# Altium schematic sheet sheet1.SchDoc
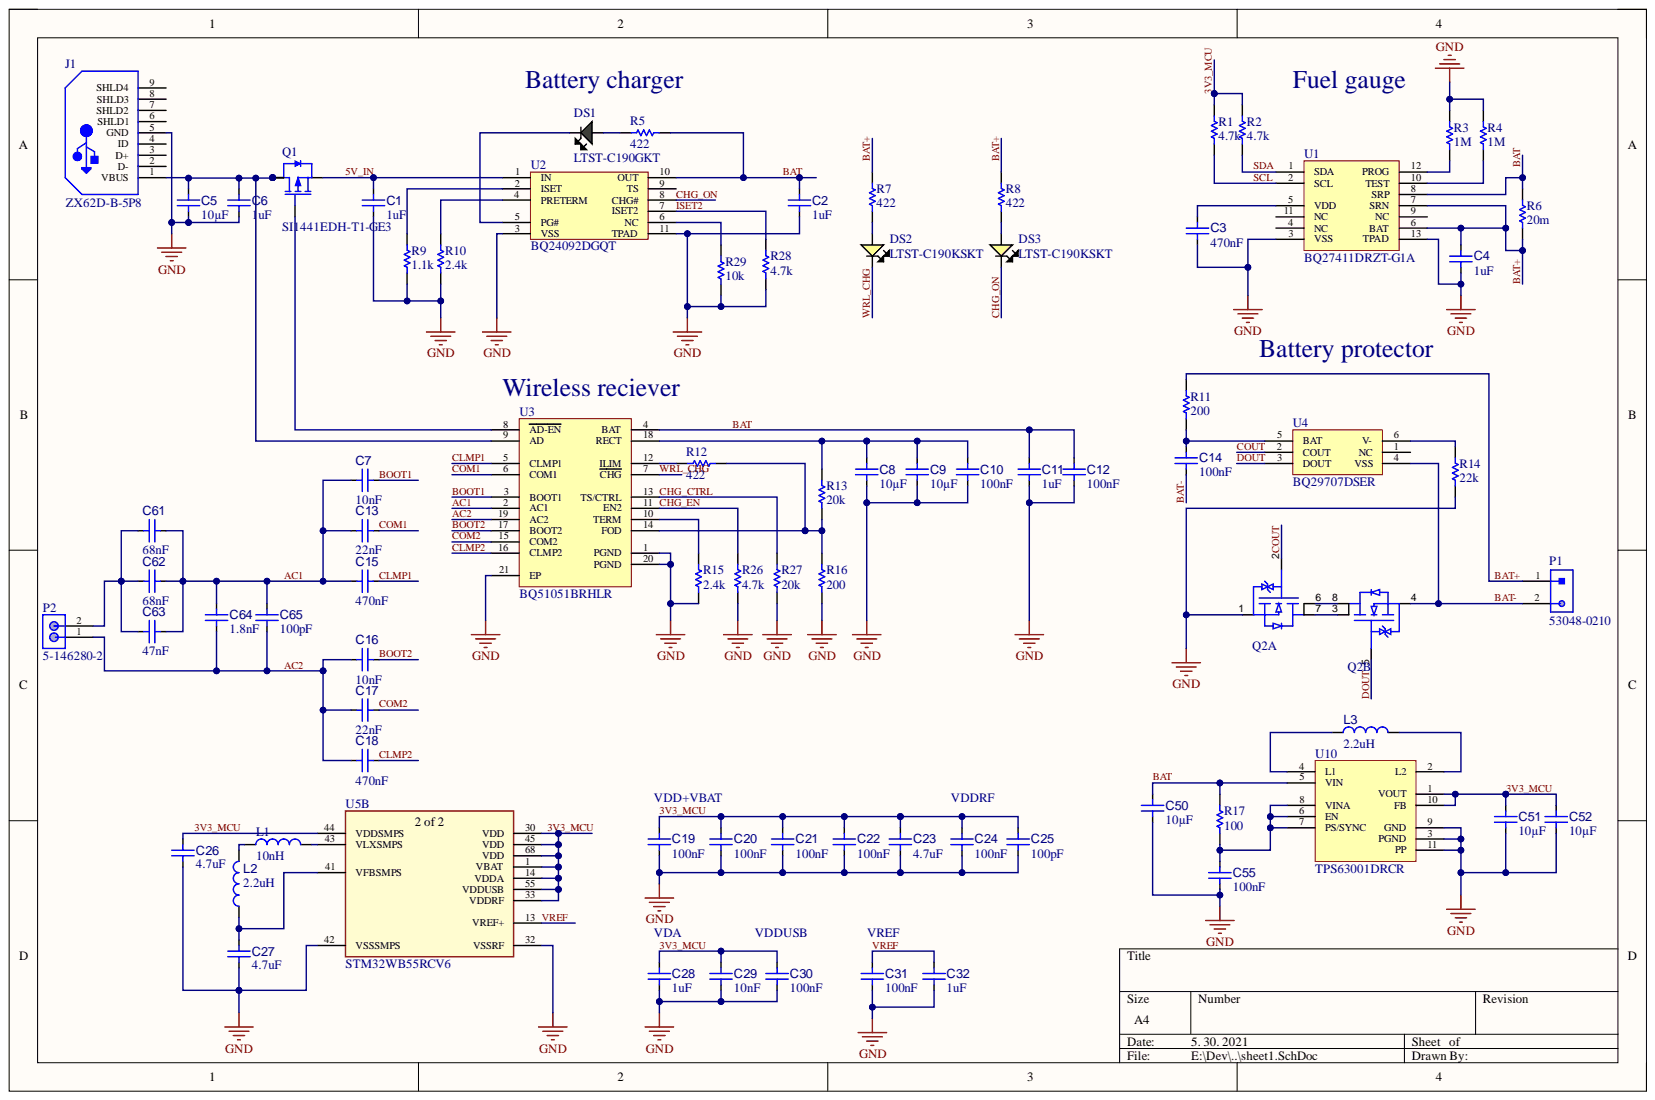
[diagram p0: sheet1.SchDoc]

## Parts
C1  value 1uF  Capacitance: 1uF  [0603-SMD-CAP]  (hidden comment: C0603C105K3PACTU)
C2  value 1uF  Capacitance: 1uF  [0603-SMD-CAP]  (hidden comment: C0603C105K3PACTU)
C3  value 470nF  [CAPC0603(1608)100_L]  (hidden comment: Capacitor 470nF +/-20% 25V 0603)
C4  value 1uF  Capacitance: 1uF  [0603-SMD-CAP]  (hidden comment: C0603C105K3PACTU)
C5  value 10µF  [0603-SMD-CAP]  (hidden comment: 0603ZD106KAT2A)
C6  value 1uF  Capacitance: 1uF  [0603-SMD-CAP]  (hidden comment: C0603C105K3PACTU)
C7  value 10nF  Capacitance: 10nF  [0402-SMD-CAP]  (hidden comment: CL05B103KB5NNNC)
C8  value 10µF  [0603-SMD-CAP]  (hidden comment: 0603ZD106KAT2A)
C9  value 10µF  [0603-SMD-CAP]  (hidden comment: 0603ZD106KAT2A)
C10  value 100nF  [0402-SMD-CAP]  (hidden comment: EMF105B7104MVHF)
C11  value 1uF  Capacitance: 1uF  [0603-SMD-CAP]  (hidden comment: C0603C105K3PACTU)
C12  value 100nF  [0402-SMD-CAP]  (hidden comment: EMF105B7104MVHF)
C13  value 22nF  Capacitance: 22nF  [0402-SMD-CAP]  (hidden comment: CC0402KRX7R8BB223)
C14  value 100nF  [0402-SMD-CAP]  (hidden comment: EMF105B7104MVHF)
C15  value 470nF  Capacitance: 470nF  [0402-SMD-CAP]  (hidden comment: C1005X5R1E474K050BB)
C16  value 10nF  Capacitance: 10nF  [0402-SMD-CAP]  (hidden comment: CL05B103KB5NNNC)
C17  value 22nF  Capacitance: 22nF  [0402-SMD-CAP]  (hidden comment: CC0402KRX7R8BB223)
C18  value 470nF  Capacitance: 470nF  [0402-SMD-CAP]  (hidden comment: C1005X5R1E474K050BB)
C19  value 100nF  [0402-SMD-CAP]  (hidden comment: EMF105B7104MVHF)
C20  value 100nF  [0402-SMD-CAP]  (hidden comment: EMF105B7104MVHF)
C21  value 100nF  [0402-SMD-CAP]  (hidden comment: EMF105B7104MVHF)
C22  value 100nF  [0402-SMD-CAP]  (hidden comment: EMF105B7104MVHF)
C23  value 4.7uF  Capacitance: 4.7uF  [0603-SMD-CAP]  (hidden comment: C0603C475K9PACTU)
C24  value 100nF  [0402-SMD-CAP]  (hidden comment: EMF105B7104MVHF)
C25  value 100pF  Capacitance: 100pF  [0402-SMD-CAP]  (hidden comment: 04025A101JAT2A)
C26  value 4.7uF  Capacitance: 4.7uF  [0603-SMD-CAP]  (hidden comment: C0603C475K9PACTU)
C27  value 4.7uF  Capacitance: 4.7uF  [0603-SMD-CAP]  (hidden comment: C0603C475K9PACTU)
C28  value 1uF  Capacitance: 1uF  [0603-SMD-CAP]  (hidden comment: C0603C105K3PACTU)
C29  value 10nF  Capacitance: 10nF  [0402-SMD-CAP]  (hidden comment: CC0402KRX7R7BB103)
C30  value 100nF  [0402-SMD-CAP]  (hidden comment: EMF105B7104MVHF)
C31  value 100nF  [0402-SMD-CAP]  (hidden comment: EMF105B7104MVHF)
C32  value 1uF  Capacitance: 1uF  [0603-SMD-CAP]  (hidden comment: C0603C105K3PACTU)
C50  value 10µF  [0603-SMD-CAP]  (hidden comment: 0603ZD106KAT2A)
C51  value 10µF  [0603-SMD-CAP]  (hidden comment: 0603ZD106KAT2A)
C52  value 10µF  [0603-SMD-CAP]  (hidden comment: 0603ZD106KAT2A)
C55  value 100nF  [0402-SMD-CAP]  (hidden comment: EMF105B7104MVHF)
C61  value 68nF  Capacitance: 68nF  [0603-SMD-CAP]  (hidden comment: 06035C683KAT2A)
C62  value 68nF  Capacitance: 68nF  [0603-SMD-CAP]  (hidden comment: 06035C683KAT2A)
C63  value 47nF  Capacitance: 47nF  [0603-SMD-CAP]  (hidden comment: 06035G473ZAT2A)
C64  value 1.8nF  Capacitance: 1.8nF  [0603-SMD-CAP]  (hidden comment: 06031C182JAT2A)
C65  value 100pF  Capacitance: 100pF  [0402-SMD-CAP]  (hidden comment: 04025A101JAT2A)
DS1  LTST-C190GKT  [LTST-C190GKT_V]
DS2  LTST-C190KSKT  [LTST-C190KSKT_V]
DS3  LTST-C190KSKT  [LTST-C190KSKT_V]
J1  ZX62D-B-5P8  [HIRO-ZX62D-B-5P8_V]
L1  value 10nH  Inductance: 10nH  [0603-SMD-IND]  (hidden comment: B82496C3100J000)
L2  value 2.2uH  [0603-SMD-IND]  (hidden comment: CVH160808-2R2M)
L3  value 2.2uH  [0603-SMD-IND]  (hidden comment: CVH160808-2R2M)
P1  53048-0210  [PHOE-1803277_V]
P2  5-146280-2  [TECO-5-146280-2_V]
Q1  SI1441EDH-T1-GE3  [SOT23127P600-8N]
Q2  CSD85301Q2  [SON65P200X80_HS-7N]  (value not drawn)  (parts A,B)
R1  value 4.7k  Resistance: 4.7k  [0603-SMD-RES]  (hidden comment: ERJ-3EKF4701V)
R2  value 4.7k  Resistance: 4.7k  [0603-SMD-RES]  (hidden comment: ERJ-3EKF4701V)
R3  value 1M  [0603-SMD-RES]  (hidden comment: CR0603-JW-105ELF)
R4  value 1M  [0603-SMD-RES]  (hidden comment: CR0603-JW-105ELF)
R5  value 422  [0402_SMD_RES]  (hidden comment: ERJ-2RKF4220X)
R6  value 20m  [Chip2PinSM127P600-8N]  (hidden comment: WSLP1206R0200FEA)
R7  value 422  [0402_SMD_RES]  (hidden comment: ERJ-2RKF4220X)
R8  value 422  [0402_SMD_RES]  (hidden comment: ERJ-2RKF4220X)
R9  value 1.1k  [0603-SMD-RES]  (hidden comment: CRCW06031K10FKEA)
R10  value 2.4k  [0603-SMD-RES]  (hidden comment: ERJ-3EKF2401V)
R11  value 200  [0402_SMD_RES]  (hidden comment: CRCW0402200RFKED)
R12  value 422  [0402_SMD_RES]  (hidden comment: ERJ-2RKF4220X)
R13  value 20k  [0402_SMD_RES]  (hidden comment: CRCW040220K0FKED)
R14  value 22k  [0603-SMD-RES]  (hidden comment: CRCW060322K0FKEA)
R15  value 2.4k  [0603-SMD-RES]  (hidden comment: ERJ-3EKF2401V)
R16  value 200  [0402_SMD_RES]  (hidden comment: CRCW0402200RFKED)
R17  value 100  [0603-SMD-RES]  (hidden comment: CRCW0603100RFKEA)
R26  value 4.7k  Resistance: 4.7k  [0603-SMD-RES]  (hidden comment: ERJ-3EKF4701V)
R27  value 20k  Resistance: 20k  [0603-SMD-RES]  (hidden comment: CRCW060320K0FKEA)
R28  value 4.7k  Resistance: 4.7k  [0603-SMD-RES]  (hidden comment: ERJ-3EKF4701V)
R29  value 10k  [0402_SMD_RES]  (hidden comment: CRCW040210K0FKTD)
U1  BQ27411DRZT-G1A  [SON40P400X100_HS-13N]
U2  BQ24092DGQT  [TSSOP50P490X110_HS-11L]
U3  BQ51051BRHLR  [RHL0020A_V]
U4  BQ29707DSER  [SON50P150X80-6N]
U5  STM32WB55RCV6  [QFN40P800X800X100_HS-69N]  (part B)
U10  TPS63001DRCR  [DRC0010J_V]

## Nets
GND: C1.2 C2.2 C3.2 C4.2 C5.1 C6.2 C8.1 C9.1 C10.2 C11.2 C12.2 C19.2 C20.2 C21.2 C22.2 C23.2 C24.2 C25.2 C26.2 C27.2 C28.2 C29.2 C30.2 C31.2 C32.2 C50.1 C51.1 C52.1 C55.2 J1.5(GND) Q2.1 R3.2 R4.2 R9.1 R10.1 R14.1 R15.1 R16.1 R26.1 R27.1 R28.1 R29.2 U1.3(VSS) U1.13(TPAD) U2.3(VSS) U2.11(TPAD) U3.1(PGND) U3.20(PGND) U3.21(EP) U5.32(VSSRF) U5.42(VSSSMPS) U10.3(PGND) U10.9(GND) U10.11(PP)
3V3_MCU: C19.1 C20.1 C21.1 C22.1 C23.1 C24.1 C25.1 C26.1 C28.1 C29.1 C30.1 C51.2 C52.2 R1.2 R2.2 U5.1(VBAT) U5.14(VDDA) U5.30(VDD) U5.33(VDDRF) U5.44(VDDSMPS) U5.45(VDD) U5.55(VDDUSB) U5.68(VDD) U10.1(VOUT) U10.10(FB)
5V_IN: C1.1 Q1.4 U2.1(IN)
AC1: C7.1 C13.1 C15.1 C61.2 C62.2 C63.2 C64.1 C65.1 U3.2(AC1)
AC2: C16.1 C17.1 C18.1 C64.2 C65.2 P2.1 U3.19(AC2)
BAT: C2.1 C11.1 C12.1 C50.2 R5.1 R6.2 R17.2 U1.8(SRP) U2.10(OUT) U3.4(BAT) U10.5(VIN)
BAT+: C4.1 P1.1 R6.1 R7.2 R8.2 R11.2 U1.6(BAT) U1.7(SRN)
BAT-: C14.2 P1.2 Q2.4 U4.4(VSS)
BOOT1: C7.2 U3.3(BOOT1)
BOOT2: C16.2 U3.17(BOOT2)
CHG_CTRL: R27.2 U3.13(TS/CTRL)
CHG_EN: R26.2 U3.11(EN2)
CHG_ON: DS3.2 U2.8(CHG#)
CLMP1: C15.2 U3.5(CLMP1)
CLMP2: C18.2 U3.16(CLMP2)
COM1: C13.2 U3.6(COM1)
COM2: C17.2 U3.15(COM2)
COUT: Q2.2 U4.2(COUT)
DOUT: Q2.5 U4.3(DOUT)
ISET2: R28.2 U2.7(ISET2)
SCL: R1.1 U1.2(SCL)
SDA: R2.1 U1.1(SDA)
VREF: C31.1 C32.1 U5.13(VREF+)
WRL_CHG: DS2.2 U3.7(CHG)
NetC3_1: C3.1 U1.5(VDD)
NetC5_2: C5.2 C6.1 J1.1(VBUS) Q1.1 Q1.2 Q1.5 Q1.6 U3.9(AD)
NetC8_2: C8.2 C9.2 C10.1 R13.2 U3.18(RECT)
NetC14_1: C14.1 R11.1 U4.5(BAT)
NetC27_1: C27.1 L2.1 U5.41(VFBSMPS)
NetC55_1: C55.1 R17.1 U10.6(EN) U10.7(PS/SYNC) U10.8(VINA)
NetC61_1: C61.1 C62.1 C63.1 P2.2
NetDS1_1: DS1.1 R5.2
NetDS1_2: DS1.2 U2.5(PG#)
NetDS2_1: DS2.1 R7.1
NetDS3_1: DS3.1 R8.1
NetL1_1: L1.1 L2.2
NetL1_2: L1.2 U5.43(VLXSMPS)
NetL3_1: L3.1 U10.4(L1)
NetL3_2: L3.2 U10.2(L2)
NetQ1_3: Q1.3 U3.8(AD-EN)
NetQ2_3: Q2.3 Q2.7
NetQ2_6: Q2.6 Q2.8
NetR3_1: R3.1 U1.12(PROG)
NetR4_1: R4.1 U1.10(TEST)
NetR9_2: R9.2 U2.2(ISET)
NetR10_2: R10.2 U2.4(PRETERM)
NetR12_1: R12.1 U3.12(ILIM)
NetR12_2: R12.2 R13.1 R16.2 U3.14(FOD)
NetR14_2: R14.2 U4.6(V-)
NetR15_2: R15.2 U3.10(TERM)
NetR29_1: R29.1 U2.6(NC)

Not connected: J1 2(D-) 3(D+) 4(ID) 6(SHLD1) 7(SHLD2) 8(SHLD3) 9(SHLD4); U1 4(NC) 9(NC) 11(NC); U2 9(TS); U4 1(NC)

## Library data of the parts
C1 C2 C4 C6 C11 C28 C32 = CMP-001-00097-3: KEMET - C0603C105K3PACTU - SMD Multilayer Ceramic Capacitor, 1 µF, 25 V, 0603 [1608 Metric], ± 10%, X5R, C Series KEMET; Voltage: 25V; Case Code (Imperial): 0603; Case Code (Metric): 1608; Case/Package: 0603; Component Kind: Standard; Construction: Flat; Contact Plating: Tin,Matte; Depth: 0.8mm; Dielectric: X5R; Dielectric Material: Ceramic; Height: 0.8mm; Lead Free: Lead Free; Length: 1.6mm; Max Operating Temperature: 85°C; Mfr. Part No.: C0603C105K3PACTU; Min Operating Temperature: -55°C; Mount: Surface Mount,PCB; Number of Pins: 2; Package Quantity: 4000; Packaging: Tape and Reel; Radiation Hardening: No; REACH SVHC: No SVHC; RoHS Compliant: Yes; Series: C0603C; Termination: SMD/SMT; Thickness: 0.034inch; Tolerance: 10%; Voltage Rating: 25V; Voltage Rating (DC): 25V; Width: 0.031inch; Library Name: Budapest University of Technology and Economics
C3 = CMP-001-00077-7: Chip Capacitor, 470nF +/-20%, 25V, 0603, Thickness 1 mm; Tolerance: 10%; Case/Package: 0603; Component Kind: Standard; Max Operating Temperature: 85°C; Min Operating Temperature: -55°C; Mounting Technology: SMT; Pins: 2; Voltage Rating: 25V; Library Name: Budapest University of Technology and Economics
C5 C8 C9 C50-C52 = CMP-001-00075-12: Chip Capacitor, 10µF +/-20%, 10V, 0603, Thickness 1 mm; Tolerance: 10%; Case/Package: 0603; Component Kind: Standard; Max Operating Temperature: 85°C; Mfr. Part No.: 0603ZD106KAT2A; Min Operating Temperature: -55°C; Mounting Technology: SMT; Pins: 2; Voltage Rating: 10V; Library Name: Budapest University of Technology and Economics
C7 C16 = CMP-001-00095-2: Cap Ceramic 0.01uF 50V X7R 10% SMD 0402 125C Paper T/R; Case Code (Imperial): 0402; Case Code (Metric): 1005; Case/Package: 0402; Component Kind: Standard; Construction: Flat; Contact Plating: Tin; Depth: 0.5mm; Dielectric: X7R; Height: 0.5mm; Lead Free: Lead Free; Length: 1mm; Material: Ceramic; Max Operating Temperature: 125°C; Min Operating Temperature: -55°C; Mount: Surface Mount; Package Quantity: 1; Packaging: Tape and Reel; Radiation Hardening: No; RoHS Compliant: Yes; Series: CL; Thickness: 0.022inch; Tolerance: 10%; Voltage: 50V; Voltage Rating: 50V; Voltage Rating (DC): 50V; Width: 0.02inch; Library Name: Budapest University of Technology and Economics
C10 C12 C14 C19-C22 C24 C30 C31 C55 = CMP-001-00001-14: Chip Capacitor, 0.1 uF, +/- 5%, 16 V, 0402 (1005 Metric); Capacitor Type: Ceramic; Voltage Rating: 16V; Case/Package: 0402; Component Kind: Standard; Max Operating Temperature: 125°C; Mfr. Part No.: EMF105B7104MVHF; Min Operating Temperature: -55°C; Mounting Technology: SM; Pins: 2; RoHS Compliant: True; Tolerance: 5%; Library Name: Budapest University of Technology and Economics
C13 C17 = CMP-001-00098-1: 0402 22 nF 25 V ±10 % Tolerance X7R SMT Multilayer Ceramic Capacitor; Tolerance: 10%; Voltage Rating: 25V; Case Code (Imperial): 0402; Case Code (Metric): 1005; Case/Package: 0402; Component Kind: Standard; Depth: 0.5mm; Dielectric: X7R; Halogen Free: Halogen Free; Height: 0.5mm; Lead Free: Lead Free; Length: 1mm; Max Operating Temperature: 125°C; Mfr. Part No.: CC0402KRX7R8BB223; Min Operating Temperature: -55°C; Mount: Surface Mount; Number of Pins: 2; Packaging: Tape and Reel; Radiation Hardening: No; Resistor Type: Ceramic; RoHS Compliant: Yes; Series: CC; Termination: SMD/SMT; Thickness: 0.022inch; Voltage Rating (DC): 25V; Weight: 2.3E-05oz; Width: 0.02inch; Library Name: Budapest University of Technology and Economics
C15 C18 = CMP-001-00100-1: TDK         C1005X5R1E474K050BB            CERAMIC CAPACITOR, 0.47UF, 25V, X5R, 0402; Dissipation Factor: 10%; Case Code (Imperial): 0402; Case Code (Metric): 1005; Case/Package: 0402; Component Kind: Standard; Construction: Flat; Depth: 0.5mm; Dielectric: X5R; Dielectric Material: Ceramic; Height: 0.5mm; Lead Free: Lead Free; Length: 1mm; Max Operating Temperature: 85°C; Mfr. Part No.: C1005X5R1E474K050BB; Min Operating Temperature: -55°C; Mount: Surface Mount; Package Quantity: 10000; Packaging: Tape and Reel; Radiation Hardening: No; REACH SVHC: No SVHC; Resistor Type: Ceramic; RoHS Compliant: Yes; Series: C; Termination: SMD/SMT; Thickness: 500um; Tolerance: 10%; Voltage: 25V; Voltage Rating: 25V; Voltage Rating (DC): 25V; Weight: 2.3E-05oz; Width: 0.02inch; Library Name: Budapest University of Technology and Economics
C23 C26 C27 = CMP-001-00092-8: KEMET - C0603C475K9PACTU - SMD Multilayer Ceramic Capacitor, 4.7 µF, 6.3 V, 0603 [1608 Metric], ± 10%, X5R, C Series KEMET; Dissipation Factor: 5%; Voltage: 6.3V; Case Code (Imperial): 0603; Case Code (Metric): 1608; Case/Package: 0603; Component Kind: Standard; Construction: Flat; Contact Plating: Tin,Matte; Depth: 0.8mm; Dielectric: X5R; Dielectric Material: Ceramic; Height: 0.8mm; Lead Free: Lead Free; Lead Pitch: 0.7mm; Length: 1.6mm; Max Operating Temperature: 85°C; Min Operating Temperature: -55°C; Mount: Surface Mount,PCB; Number of Pins: 2; Package Quantity: 1; Packaging: Tape and Reel; Radiation Hardening: No; REACH SVHC: No SVHC; RoHS Compliant: Yes; Series: C; Termination: SMD/SMT; Thickness: 0.035inch; Tolerance: 10%; Voltage Rating: 6.3V; Voltage Rating (DC): 6.3V; Width: 0.031inch; Library Name: Budapest University of Technology and Economics
C25 C65 = CMP-001-00004-1: Capacitor, Ceramic; 100pf; Chip; Case 0402; Npo; +/-5%; 50wvdc; Smd; 2.5% Df; Tr; Dielectric: C0G; Insulation Resistance: 1GR; Temperature Coefficient: 30ppm/°C; Tolerance: 5%; Voltage: 50V; Case Code (Imperial): 0402; Case Code (Metric): 1005; Case/Package: 0402; Component Kind: Standard; Construction: Flat; Contact Plating: Tin,Matte; Depth: 0.5mm; Dissipation Factor: 2.5%; Height: 0.56mm; Lead Free: Lead Free; Length: 1mm; Max Operating Temperature: 125°C; Mfr. Part No.: 04025A101JAT2A; Min Operating Temperature: -55°C; Mount: Surface Mount,PCB; Package Quantity: 1; Packaging: Tape and Reel; Radiation Hardening: No; REACH SVHC: No SVHC; RoHS Compliant: Yes; Series: -; Termination: SMD/SMT; Thickness: 0.022inch; Voltage Rating: 50V; Voltage Rating (DC): 50V; Width: 0.02inch; Library Name: Budapest University of Technology and Economics
C29 = CMP-001-00003-1: CAP CER 10000PF 16V X7R 0402; Dissipation Factor: 3.5%; Insulation Resistance: 10GR; Tolerance: 10%; Case Code (Imperial): 0402; Case Code (Metric): 1005; Case/Package: 0402; Component Kind: Standard; Depth: 0.5mm; Dielectric: X7R; Halogen Free: Halogen Free; Height: 0.5mm; Lead Free: Lead Free; Length: 1mm; Max Operating Temperature: 125°C; Mfr. Part No.: CC0402KRX7R7BB103; Min Operating Temperature: -55°C; Mount: Surface Mount; Number of Pins: 2; Package Quantity: 1; Packaging: Tape and Reel; Radiation Hardening: No; REACH SVHC: No SVHC; RoHS Compliant: Yes; Series: CC; Termination: SMD/SMT; Thickness: 0.022inch; Voltage: 16V; Voltage Rating: 16V; Voltage Rating (DC): 16V; Width: 0.02inch; Library Name: Budapest University of Technology and Economics
C61 C62 = CMP-001-00013-1: Cap Ceramic 0.068uF 50V X7R 10% SMD 0603 125C Paper T/R; Dissipation Factor: 2.5%; Case Code (Imperial): 0603; Case Code (Metric): 1608; Case/Package: 0603; Component Kind: Standard; Construction: Flat; Contact Plating: Tin,Matte; Depth: 0.81mm; Dielectric: X7R; Height: 0.9mm; Lead Free: Lead Free; Length: 1.6mm; Max Operating Temperature: 125°C; Mfr. Part No.: 06035C683KAT2A; Min Operating Temperature: -55°C; Mount: Surface Mount; Number of Pins: 2; Package Quantity: 4000; Packaging: Tape and Reel; Radiation Hardening: No; REACH SVHC: Unknown; RoHS Compliant: Yes; Series: -; Termination: SMD/SMT; Thickness: 0.035inch; Tolerance: 10%; Voltage: 50V; Voltage Rating: 50V; Voltage Rating (DC): 50V; Width: 0.81mm; Library Name: Budapest University of Technology and Economics
C63 = CMP-001-00012-2: AVX 47nF Multilayer Ceramic Capacitor (MLCC) 50 V dc -20  80% Y5V dielectric Standard Surface Mount; Voltage: 50V; Case Code (Imperial): 0603; Case Code (Metric): 1608; Case/Package: 0603; Component Kind: Standard; Construction: Flat; Depth: 0.81mm; Dielectric: Y5V; Dielectric Material: Ceramic; Height: 0.9mm; Lead Free: Lead Free; Length: 0.063inch; Max Operating Temperature: 85°C; Mfr. Part No.: 06035G473ZAT2A; Min Operating Temperature: -30°C; Mount: Surface Mount; Number of Pins: 2; Package Quantity: 1; Packaging: Tape and Reel; Radiation Hardening: No; REACH SVHC: No SVHC; RoHS Compliant: Yes; Series: -; Termination: SMD/SMT; Thickness: 0.035inch; Tolerance: 80%; Voltage Rating: 50V; Voltage Rating (DC): 50V; Width: 0.032inch; Library Name: Budapest University of Technology and Economics
C64 = CMP-001-00011-1: AVX - 06031C182JAT2A - SMD Multilayer Ceramic Capacitor, 1800 pF, 100 V, 0603 [1608 Metric], ± 5%, X7R, AVX 0603 MLCC; Dissipation Factor: 2.5%; Voltage Rating: 100V; Case Code (Imperial): 0603; Case Code (Metric): 1608; Case/Package: 0603; Component Kind: Standard; Construction: Flat; Contact Plating: Tin,Matte; Depth: 0.81mm; Dielectric: X7R; Dielectric Material: Ceramic; Lead Free: Lead Free; Length: 1.6mm; Max Operating Temperature: 125°C; Mfr Part No.: 06031C182JAT2A; Min Operating Temperature: -55°C; Mount: Surface Mount; Package Quantity: 1; Packaging: Tape and Reel; Radiation Hardening: No; REACH SVHC: No SVHC; RoHS Compliant: Yes; Series: -; Termination: SMD/SMT; Thickness: 0.035inch; Tolerance: 5%; Voltage Rating (DC): 100V; Width: 0.81mm; Library Name: Budapest University of Technology and Economics
DS1 = CMP-007-00022-2: LED Uni-Color Green, 100 mW, 30 mA, -55 to 85 degC, 2-Pin SMD, RoHS, Tape and Reel; Manufacturer: Lite-On; Forward Current: 20mA; Forward Voltage: 2.1V; Lens Style: Clear; Luminous Intensity: 6mcd; Power: 100mW; Viewing Angle: 130degrees; Case/Package: 0603; Colour: Green; Component Kind: Standard; Datasheet URL: http://datasheet.octopart.com/LTST-C190GKT-Lite-On-datasheet-158530.pdf; Datasheet Version: Dec-06; Illumination Colour: Green; Manufacturer URL: http://www.us.liteon.com/; Max Operating Temperature: 85°C; Min Operating Temperature: -55°C; Mounting Technology: SM; Nominal Vf: 2.1V; Package Description: 2-Pin Surface Mount Device, Body 1.6 x 0.8 mm; Package Quantity: 3000; Package Reference: C190GKT; Packaging: TapeandReel; Pins: 2; RoHS Compliant: True; Library Name: Budapest University of Technology and Economics
DS2 DS3 = CMP-007-00025-2: LED Uni-Color Yellow, 75 mW, 30 mA, -30 to 85 degC, 2-Pin SMD, RoHS, Tape and Reel; Manufacturer: Lite-On; Forward Current: 20mA; Forward Voltage: 2V; Lens Style: Diffused; Luminous Intensity: 28mcd; Nominal Vf: 2.1V; Power: 75mW; Viewing Angle: 130degrees; Case/Package: 0603; Colour: Yellow; Component Kind: Standard; Datasheet URL: http://optoelectronics.liteon.com/upload/download/DS-22-99-0189/S_110_LTST-C190KSKT.pdf; Datasheet Version: Rev. I, 10/2012; Illumination Colour: Yellow; Manufacturer URL: http://www.us.liteon.com/; Max Operating Temperature: 85°C; Min Operating Temperature: -55°C; Mounting Technology: SM; Package Description: 2-Pin Surface Mount Device, Body 1.6 x 0.8 mm; Package Quantity: 3000; Package Reference: C190KSKT; Packaging: TapeandReel; Pins: 2; RoHS Compliant: True; Library Name: Budapest University of Technology and Economics
J1 = CMP-002-00043-3: Right Angle Receptacle Connector, Micro USB, 5 Position, Height 2.65 mm, -30 to 85 degC, RoHS, Tape and Reel; Manufacturer: Hirose Electric; Current Rating: 1A; Gender: Male; Rows: 1; Case/Package: ZX62D-B-5P8; Component Kind: Standard; Connector Type: USB; Contact Material: Copper; Contact Plating: Gold; Datasheet URL: https://www.hirose.com/product/en/download_file/key_name/ZX62D-B-5P8/category/Catalog/doc_file_id/31704/?file_category_id=5&item_id=02420034000&is_series=; Datasheet Version: Aug-16; Housing Material: Plastic; Lead Pitch: 0.65mm; Manufacturer URL: https://www.hirose.com; Max Operating Temperature: 85°C; Min Operating Temperature: -30°C; Mounting Technology: SM; Package Description: USB Connector, 5 Position Surface Mount, Length 8 mm, Height 2.65 mm; Package Quantity: 2000; Package Reference: ZX62D-B-5P8; Package URL: https://www.hirose.com/product/en/download_file/key_name/ZX62D-B-5P8/category/Drawing%20(2D)/doc_file_id/36535/?file_category_id=6&item_id=02420034000&is_series=; Packaging: TapeandReel; Pins: 5; RoHS Compliant: True; Library Name: Budapest University of Technology and Economics
L1 = CMP-006-00033-1: EPCOS   B82496C3100J   INDUCTOR, 0603 CASE, 10NH; Core Material: Copper,Ceramic; Current Rating: 400mA; DC Current: 600mA; DC Resistance (DCR): 200mR; Inductance Tolerance: 5%; Inductor Type: Wirewound; Q Factor: 14; Self Resonant Frequency: 5GHz; Series Resistance: 320mR; Shielding: Unshielded; Test Frequency: 100MHz; Case Code (Imperial): 0603; Case Code (Metric): 1608; Case/Package: 0603; Component Kind: Standard; Contact Plating: Tin; Depth: 0.9mm; Height: 0.8mm; Height - Seated (Max): 0.035inch; Lead Free: Lead Free; Length: 1.6mm; Max DC Current: 600mA; Max Operating Temperature: 125°C; Mfr. Part No.: B82496C3100J000; Military Standard: Not; Mount: Surface Mount; Number of Pins: 2; Package Quantity: 4000; Packaging: Tape and Reel; Radiation Hardening: No; Ratings: AEC-Q200; REACH SVHC: Unknown; Resistor Type: Wirewound; RoHS Compliant: Yes; Series: B82496C; Termination: SMD/SMT; Tolerance: 5%; Weight: 0.000141oz; Width: 0.031inch; Library Name: Budapest University of Technology and Economics
L2 L3 = CMP-006-00032-1: Inductor Power Shielded Multi-Layer 2.2uH 20% 1MHz Ferrite 0.75A 0.3Ohm DCR 0603 T/R; Current Rating: 750mA; Self Resonant Frequency: 50MHz; Case/Package: 0603; Component Kind: Standard; Core Material: Ferrite; Max Operating Temperature: 85°C; Mfr. Part No.: CVH160808-2R2M; Min Operating Temperature: -40°C; RoHS Compliant: Yes; Shielding: Shielded; Library Name: Budapest University of Technology and Economics
P1 = CMP-002-00086-2: MOLEX - 53048-0210 - Wire-To-Board Connector, Right Angle, 1.25 mm, 2 Contacts, Header, PicoBlade 53048 Series; Contact Resistance: 20mR; Current Rating: 1A; Gender: Male; Glow Wire Compliant: Non-compliant; Insulation Resistance: 100MR; Number of Circuits: 2; Pitch: 1.25mm; Voltage Rating: 125V; Color: Natural; Component Kind: Standard; Connector Type: Header; Contact Material: Bronze,Brass,Tin; Contact Plating: Tin; Flammability Rating: UL94 V-0; Housing Color: Natural; Housing Material: Nylon; Lead Free: Lead Free; Max Operating Temperature: 85°C; Min Operating Temperature: -40°C; Mount: Through Hole; Number of Contacts: 2; Number of Positions: 2; Number of Rows: 1; Orientation: Right Angle; Package Quantity: 5000; Packaging: Tray; Radiation Hardening: No; REACH SVHC: Unknown; RoHS Compliant: Yes; Series: PicoBlade; Termination: Through Hole; Voltage Rating (DC): 125V; Library Name: Budapest University of Technology and Economics
P2 = CMP-002-00087-2: AMPMODU 2 Position 2.54 mm Single Row Through-Hole Straight Breakaway Header; Approvals: CSA; Board Standoff: No (Without); Contact Current Rating: 5A; Contact Post Length: 0.12inch; Current Rating: 3A; High Speed Serial Data Connector: No; High Temperature Housing: Yes; Number of Contacts: 2; PCB Mount Retention: Without; PCB Mounting Orientation: Vertical; PCB Thickness: 0.063inch; Pitch: 2.54mm; Color: Black; Component Kind: Standard; Connector Type: Header; Contact Finish - Mating: GOLD; Contact Gender: Male; Contact Material: Copper Alloy; Contact Mating Length: 0.23inch; Contact Plating: Gold,Tin,Lead,Matte; Contact Protection: No (Without); Contact Shape: Square; Contact Type: Male Pin; Depth: 2.34mm; Fastening Type: Pull; Flammability Rating: UL94 V-0; Gasket: No (Without); Housing Color: Black; Housing Material: Polymer; Insulation Color: Black; Insulation Material: Polymer; Keyed: No; Length: 4.67mm; Mating Alignment: No (Without); Mating Connector Lock: No (Without); Max Operating Temperature: 105°C; Mfr. Part No.: 5-146280-2; Min Operating Temperature: -65°C; Mount: Through Hole; Number of Positions: 2; Number of Rows: 1; Orientation: Straight; Package Quantity: 500; Packaging: Carton; Pins: 2; Radiation Hardening: No; Row Spacing: 2.54mm; Selectively Loaded: No (Without); Series: AMPMODU MOD II; Stabilizers: No (Without); Termination: Through Hole; Library Name: Budapest University of Technology and Economics
Q1 = CMP-013-00036-1: MOSFET -20V 41mOhm@4.5V 4A P-Ch G-III; Drain to Source Resistance: 41mR; Drain to Source Voltage (Vdss): 20V; Element Configuration: Single; Gate to Source Voltage (Vgs): 10V; Max Power Dissipation: 2.8W; Nominal Vgs: -400mV; Number of Channels: 1; Polarity: P-CHANNEL; Power Dissipation: 1.6W; Case/Package: SOT-363; Component Kind: Standard; Continuous Drain Current (ID): 4A; Drain to Source Breakdown Voltage: -20V; Lead Free: Lead Free; Max Operating Temperature: 150°C; Min Operating Temperature: -55°C; Mount: Surface Mount; Number of Elements: 1; Number of Pins: 6; Package Quantity: 3000; Packaging: Tape and Reel; Radiation Hardening: No; Rds On Max: 41mR; REACH SVHC: Unknown; RoHS Compliant: Yes; Series: TrenchFET®; Weight: 0.000265oz; Library Name: Budapest University of Technology and Economics
Q2 = CMP-013-00037-2: MOSFET 2N-CH 20V 5A 6WSON; Drain to Source Resistance: 23mR; Element Configuration: Dual; Fall Time: 15ns; FET Type: 2 N-Channel; Gate to Source Voltage (Vgs): 10V; Input Capacitance: 469pF; Max Power Dissipation: 2.3W; Number of Channels: 2; Rds On Max: 27mR; Rise Time: 26ns; Turn-Off Delay Time: 14ns; Turn-On Delay Time: 6ns; Component Kind: Standard; Contact Plating: Tin; Continuous Drain Current (ID): 5A; Drain to Source Voltage (Vdss): 20V; Height: 0.8mm; Lead Free: Lead Free; Length: 2.1mm; Max Operating Temperature: 150°C; Mfr. Part No.: CSD85301Q2; Min Operating Temperature: -55°C; Mount: Surface Mount; Number of Pins: 6; Package Quantity: 3000; Packaging: Tape and Reel; RoHS Compliant: Yes; Weight: 0.000342oz; Width: 2.1mm; Library Name: Budapest University of Technology and Economics
R1 R2 R26 R28 = CMP-009-00190-2: RESISTOR, 0603, 0.1W, 1%, 4K7; Max Power Dissipation: 100mW; Temperature Coefficient: 100ppm/°C; Voltage Rating: 75V; Case Code (Imperial): 0603; Case Code (Metric): 1608; Case/Package: 0603; Component Kind: Standard; Construction: Rectangular; Contact Plating: Tin; Depth: 0.8mm; Features: Automotive AEC-Q200; Height: 0.022inch; Lead Free: Lead Free; Length: 1.6mm; Max Operating Temperature: 155°C; Military Standard: Not; Min Operating Temperature: -55°C; Mount: Surface Mount; Number of Pins: 2; Number of Terminations: 2; Package Quantity: 1; Packaging: Tape and Reel; Power Rating: 100mW; Radiation Hardening: No; REACH SVHC: No SVHC; Resistance Tolerance: 1%; Resistor Type: Thick Film; RoHS Compliant: Yes; Series: ERJ; Size Code: 0603; Termination: SMD/SMT; Tolerance: 1%; Voltage Rating (DC): 75V; Weight: 7.1E-05oz; Width: 0.031inch; Library Name: Budapest University of Technology and Economics
R3 R4 = CMP-009-00008-2: Res Thick Film 0603 1M Ohm 5% 1/10W ±200ppm/C Molded SMD SMD Paper Tape on Plastic Reel; Max Power Dissipation: 100mW; Resistance: 1MR; Temperature Coefficient: 200ppm/°C; Voltage Rating: 50V; Case Code (Imperial): 0603; Case Code (Metric): 1608; Case/Package: 0603; Component Kind: Standard; Construction: Rectangular; Contact Plating: Tin; Depth: 0.8mm; Features: Moisture Resistant; Height: 0.022inch; Length: 1.6mm; Max Operating Temperature: 155°C; Mfr. Part No.: CR0603-JW-105ELF; Military Standard: Not; Min Operating Temperature: -55°C; Mount: Surface Mount; Number of Terminations: 2; Package Quantity: 1; Packaging: Tape and Reel; Power Rating: 100mW; Radiation Hardening: No; Resistor Type: Thick Film; RoHS Compliant: Yes; Series: CR0603; Termination: SMD/SMT; Thickness: 457um; Tolerance: 5%; Width: 0.031inch; Library Name: Budapest University of Technology and Economics
R5 R7 R8 R12 = CMP-009-00196-5: Res Thick Film 0402 422 Ohm 1% 0.1W(1/10W) ±100ppm/C Molded SMD Automotive Punched T/R; Max Power Dissipation: 100mW; Resistance: 422R; Temperature Coefficient: 100ppm/°C; Voltage Rating: 50V; Case Code (Imperial): 0402; Case Code (Metric): 1005; Case/Package: 0402; Component Kind: Standard; Construction: Rectangular; Contact Plating: Tin; Depth: 0.5mm; Features: Automotive AEC-Q200; Height: 0.35mm; Lead Free: Lead Free; Length: 1mm; Max Operating Temperature: 155°C; Mfr. Part No.: ERJ-2RKF4220X; Military Standard: Not; Min Operating Temperature: -55°C; Mount: Surface Mount; Number of Terminations: 2; Package Quantity: 1; Packaging: Tape and Reel; Power Rating: 100mW; REACH SVHC: No SVHC; Resistance Tolerance: 1%; Resistor Type: Thick Film; RoHS Compliant: Yes; Series: ERJ; Technology: THICK FILM; Termination: SMD/SMT; Tolerance: 1%; Voltage Rating (DC): 50V; Width: 0.02inch; Library Name: Budapest University of Technology and Economics
R6 = CMP-009-00007-1: Res Metal Strip 1206 0.02 Ohm 1% 1W 75ppm/C Molded SMD SMD T/R; Resistance: 20mR; Temperature Coefficient: 75ppm/°C; Case Code (Imperial): 1206; Case Code (Metric): 3216; Case/Package: 1206; Component Kind: Standard; Construction: Rectangular; Depth: 1.6mm; Features: Moisture Resistant; Height: 0.035inch; Lead Free: Lead Free; Length: 3.2mm; Max Operating Temperature: 170°C; Max Power Dissipation: 1W; Mfr. Part No.: WSLP1206R0200FEA; Military Standard: Not; Min Operating Temperature: -65°C; Mount: Surface Mount; Number of Terminations: 2; Package Quantity: 1; Packaging: Tape and Reel; Power Rating: 1W; Radiation Hardening: No; REACH SVHC: Unknown; Resistance Tolerance: 1%; Resistor Type: Metal Strip; RoHS Compliant: Yes; Series: WSLP; Size Code: 1206; Termination: SMD/SMT; Tolerance: 1%; Width: 1.6mm; Library Name: Budapest University of Technology and Economics
R9 = CMP-009-00005-2: Resistor, Thick Film; 1.1 Kilohms; 0.10 W @   degC; + 1%; 75 V; 0603; -55  degC; Max Power Dissipation: 100mW; Resistance: 1.1kR; Temperature Coefficient: 100ppm/°C; Voltage Rating: 75V; Case Code (Imperial): 0603; Case Code (Metric): 1608; Case/Package: 0603; Component Kind: Standard; Construction: Rectangular; Contact Plating: Tin; Depth: 0.85mm; Features: Automotive AEC-Q200; Height: 0.02inch; Lead Free: Lead Free; Length: 1.55mm; Max Operating Temperature: 155°C; Mfr. Part No.: CRCW06031K10FKEA; Military Standard: Not; Min Operating Temperature: -55°C; Number of Pins: 2; Number of Terminations: 2; Package Quantity: 10; Packaging: Tape and Reel; Power Rating: 100mW; Radiation Hardening: No; REACH SVHC: Unknown; Resistance Tolerance: 1%; Resistor Type: Thick Film; RoHS Compliant: Yes; Series: CRCW; Size Code: 0603; Termination: SMD/SMT; Tolerance: 1%; Voltage Rating (DC): 75V; Weight: 7.1E-05oz; Width: 0.033inch; Library Name: Budapest University of Technology and Economics
R10 R15 = CMP-009-00002-2: Res Thick Film 0603 2.4K Ohm 1% 0.1W(1/10W) }100ppm/C Molded SMD Automotive Punched T/R; Max Power Dissipation: 100mW; Resistance: 2.4kR; Temperature Coefficient: 100ppm/°C; Voltage Rating: 75V; Case Code (Imperial): 0603; Case Code (Metric): 1608; Case/Package: 0603; Component Kind: Standard; Construction: Rectangular; Contact Plating: Tin; Depth: 0.8mm; Features: Automotive AEC-Q200; Height: 0.022inch; Lead Free: Lead Free; Length: 1.6mm; Max Operating Temperature: 155°C; Mfr. Part No.: ERJ-3EKF2401V; Military Standard: Not; Min Operating Temperature: -55°C; Mount: Surface Mount; Number of Terminations: 2; Package Quantity: 1; Packaging: Tape and Reel; Power Rating: 100mW; Radiation Hardening: No; REACH SVHC: No SVHC; Resistance Tolerance: 1%; Resistor Type: Thick Film; RoHS Compliant: Yes; Series: ERJ; Termination: SMD/SMT; Tolerance: 1%; Voltage Rating (DC): 75V; Width: 0.031inch; Library Name: Budapest University of Technology and Economics
R11 R16 = CMP-009-00197-3: SMD Chip Resistor, 0402 [1005 Metric], 200 ohm, CRCW e3 Series, 50 V, Thick Film, 63 mW; Max Power Dissipation: 63mW; Resistance: 200R; Resistance Tolerance: 1%; Temperature Coefficient: 100ppm/°C; Voltage Rating: 50V; Case Code (Imperial): 0402; Case Code (Metric): 1005; Case/Package: 0402; Component Kind: Standard; Construction: Rectangular; Contact Plating: Tin; Depth: 0.5mm; Features: Automotive AEC-Q200; Height: 0.35mm; Lead Free: Lead Free; Length: 1mm; Max Operating Temperature: 155°C; Mfr. Part No.: CRCW0402200RFKED; Military Standard: Not; Min Operating Temperature: -55°C; Mount: Surface Mount; Number of Pins: 2; Number of Terminations: 2; Package Quantity: 10; Packaging: Tape and Reel; Power Rating: 63mW; Radiation Hardening: No; REACH SVHC: Unknown; Resistor Type: Thick Film; RoHS Compliant: Yes; Series: CRCW; Size Code: 0402; Technology: THICK FILM; Termination: SMD/SMT; Tolerance: 1%; Voltage Rating (DC): 50V; Weight: 2.3E-05oz; Width: 0.5mm; Library Name: Budapest University of Technology and Economics
R13 = CMP-009-00198-3: RES SMD 20K OHM 1% 1/16W 0402; Max Power Dissipation: 63mW; Resistance: 20kR; Temperature Coefficient: 100ppm/°C; Voltage Rating: 50V; Case Code (Imperial): 0402; Case Code (Metric): 1005; Case/Package: 0402; Component Kind: Standard; Construction: Rectangular; Contact Plating: Tin; Depth: 0.5mm; Features: Automotive AEC-Q200; Height: 0.35mm; Lead Free: Lead Free; Length: 1mm; Max Operating Temperature: 155°C; Mfr. Part No.: CRCW040220K0FKED; Military Standard: Not; Min Operating Temperature: -55°C; Mount: Surface Mount; Number of Pins: 2; Number of Terminations: 2; Package Quantity: 10; Packaging: Tape and Reel; Power Rating: 63mW; Radiation Hardening: No; REACH SVHC: Unknown; Resistance Tolerance: 1%; Resistor Type: Thick Film; RoHS Compliant: Yes; Series: CRCW; Technology: THICK FILM; Termination: SMD/SMT; Tolerance: 1%; Voltage Rating (DC): 50V; Weight: 2.3E-05oz; Width: 0.5mm; Working Voltage: 50V; Library Name: Budapest University of Technology and Economics
R14 = CMP-009-00195-2: VISHAY - CRCW060322K0FKEA - Surface Mount Thick Film Resistor, AEC-Q200 CRCW Series, 22 kohm, 100 mW, - 1%, 75 V RoHS Compliant: Yes; Max Power Dissipation: 100mW; Resistance: 22kR; Temperature Coefficient: 100ppm/°C; Voltage Rating: 75V; Case Code (Imperial): 0603; Case Code (Metric): 1608; Case/Package: 0603; Component Kind: Standard; Construction: Rectangular; Contact Plating: Tin; Depth: 0.85mm; Features: Automotive AEC-Q200; Height: 0.45mm; Lead Free: Lead Free; Length: 1.55mm; Max Operating Temperature: 155°C; Military Standard: Not; Min Operating Temperature: -55°C; Mount: Surface Mount; Number of Terminations: 2; Package Quantity: 10; Packaging: Tape and Reel; Power Rating: 100mW; Radiation Hardening: No; REACH SVHC: Unknown; Resistance Tolerance: 1%; Resistor Type: Thick Film; RoHS Compliant: Yes; Series: CRCW; Size Code: 0603; Technology: THICK FILM; Termination: SMD/SMT; Tolerance: 1%; Voltage Rating (DC): 75V; Weight: 7.1E-05oz; Width: 0.85mm; Library Name: Budapest University of Technology and Economics
R17 = CMP-009-00009-3: Res Thick Film 0603 100 Ohm 1% 1/10W ±100ppm/°C Molded SMD Paper T/R; Max Power Dissipation: 100mW; Resistance: 100R; Voltage Rating: 75V; Case Code (Imperial): 0603; Case Code (Metric): 1608; Case/Package: 0603; Component Kind: Standard; Construction: Rectangular; Contact Plating: Tin; Depth: 0.85mm; Features: Automotive AEC-Q200; Height: 0.02inch; Lead Free: Lead Free; Length: 1.55mm; Max Operating Temperature: 155°C; Mfr. Part No.: CRCW0603100RFKEA; Military Standard: Not; Min Operating Temperature: -55°C; Mount: Surface Mount; Number of Pins: 2; Number of Terminations: 2; Package Quantity: 10; Packaging: Tape and Reel; Power Rating: 100mW; Radiation Hardening: No; REACH SVHC: Unknown; Resistance Tolerance: 1%; Resistor Type: Thick Film; RoHS Compliant: Yes; Series: CRCW; Size Code: 0603; Temperature Coefficient: 100ppm/°C; Termination: SMD/SMT; Tolerance: 1%; Voltage Rating (DC): 75V; Weight: 7.1E-05oz; Width: 0.033inch; Library Name: Budapest University of Technology and Economics
R27 = CMP-009-00191-6: VISHAY - CRCW060320K0FKEA - SMD Chip Resistor, 0603 [1608 Metric], 20 kohm, CRCW e3 Series, 75 V, Thick Film, 100 mW; Max Power Dissipation: 100mW; Resistance Tolerance: 1%; Temperature Coefficient: 100ppm/°C; Voltage Rating: 75V; Case Code (Imperial): 0603; Case Code (Metric): 1608; Case/Package: 0603; Component Kind: Standard; Construction: Rectangular; Contact Plating: Tin,Lead; Depth: 0.85mm; Features: Automotive AEC-Q200; Height: 0.45mm; Lead Free: Lead Free; Length: 1.55mm; Max Operating Temperature: 155°C; Military Standard: Not; Min Operating Temperature: -55°C; Mount: Surface Mount; Number of Pins: 2; Number of Terminations: 2; Package Quantity: 5000; Packaging: Tape and Reel; Power Rating: 100mW; Radiation Hardening: No; REACH SVHC: Unknown; Resistor Type: Thick Film; RoHS Compliant: Yes; Series: CRCW; Size Code: 0603; Termination: SMD/SMT; Tolerance: 1%; Voltage Rating (DC): 75V; Weight: 7.1E-05oz; Width: 0.85mm; Library Name: Budapest University of Technology and Economics
R29 = CMP-009-00149-11: Chip Resistor, 10 kOhms, +/-1 %, 63 mW, -55 to 125 degC, 0402; Power: 63mW; Tolerance: 1%; Case/Package: 0402; Component Kind: Standard; Max Operating Temperature: 155°C; Mfr. Part No.: CRCW040210K0FKTD; Min Operating Temperature: -55°C; Pins: 2; Library Name: Budapest University of Technology and Economics
U1 = CMP-019-00060-3: IC BATT FUEL GAUGE LICOO2 12SON; Capacity: 8000mAh; Interface: I2C; Max Supply Voltage: 4.5V; Min Supply Voltage: 2.45V; Number of Cells: 1; Operating Supply Current: 93uA; Output Current: 500uA; Output Voltage: 1.8V; Component Kind: Standard; Contact Plating: Gold; Lead Free: Lead Free; Max Operating Temperature: 85°C; Min Operating Temperature: -40°C; Mount: Surface Mount; Number of Pins: 12; Package Quantity: 250; Packaging: Tape and Reel; REACH SVHC: No SVHC; RoHS Compliant: Yes; Library Name: Budapest University of Technology and Economics
U2 = CMP-019-00058-5: Battery Charger Li-Ion/Li-Pol 1000mA 4.2V 10-Pin MSOP EP T/R; Max Charge Current: 1A; Max Output Voltage: 4.23V; Max Supply Voltage: 12V; Min Input Voltage: 4.5V; Min Output Voltage: 4.16V; Min Supply Voltage: 3.5V; Number of Cells: 1; Operating Supply Current: 800uA; Operating Supply Voltage: 6.5V; Case/Package: MSOP; Component Kind: Standard; Contact Plating: Gold; Height: 0.95mm; Lead Free: Lead Free; Length: 3.1mm; Max Operating Temperature: 125°C; Max Output Current: 1A; Mfr. Part No.: BQ24092DGQT; Min Operating Temperature: -40°C; Number of Pins: 10; Output Current: 1A; Output Voltage: 4.2V; Package Quantity: 1; Packaging: Tape and Reel; Radiation Hardening: No; REACH SVHC: No SVHC; RoHS Compliant: Yes; Series: -; Width: 3.1mm; Library Name: Budapest University of Technology and Economics
U3 = CMP-019-00057-4: IC WIRELESS PWR RCVR 20QFN; Logic Function: Receiver; Operating Supply Current: 8mA; Operating Supply Voltage: 10V; Output Current: 1.5A; Output Voltage: 4.35V; Case/Package: VQFN; Component Kind: Standard; Contact Plating: Gold; Lead Free: Lead Free; Max Operating Temperature: 125°C; Min Operating Temperature: 0°C; Mount: Surface Mount; Package Quantity: 3000; Packaging: Tape and Reel; RoHS Compliant: Yes; Series: BQ51051B; Library Name: Budapest University of Technology and Economics
U4 = CMP-019-00059-3: IC LITHIUM BATT PROTECT 6WSON; Fault Protection: Over Current,Short Circuit,Over/Under Voltage; Number of Cells: 1; Operating Supply Voltage: 28V; Case/Package: WFDFN; Component Kind: Standard; Contact Plating: Gold; Lead Free: Lead Free; Max Operating Temperature: 85°C; Min Operating Temperature: -40°C; Mount: Surface Mount; Number of Pins: 6; Packaging: Tape and Reel; RoHS Compliant: Yes; Library Name: Budapest University of Technology and Economics
U5 = CMP-020-00023-5: ARM Based Multiprotocol Wireless Microcontroller 32-Bit 1.71V to 3.6V 68-Pin 256KB VFQFPN Surface Mount; Manufacturer: ST; Frequence: 64MHz; Case/Package: VFQFPN; Component Kind: Standard; Flash size: 256kB; Max Operating Temperature: 85°C; Max Supply Voltage: 3.6V; Min Operating Temperature: -40°C; Min Supply Voltage: 1.71V; Library Name: Budapest University of Technology and Economics
U10 = CMP-019-00064-1: TEXAS INSTRUMENTS         TPS63001DRCR             CONVERTER, BUCK-BOOST, SON-10, FULL REEL; Efficiency: 96%; Max Input Voltage: 5.5V; Max Output Current: 1.2A; Max Output Voltage: 3.3V; Min Input Voltage: 1.8V; Number of Outputs: 1; Output Type: Fixed; Quiescent Current: 30uA; Switching Frequency: 1.5MHz; Synchronous Rectifier: Yes; Component Kind: Standard; Contact Plating: Gold; Lead Free: Lead Free; Max Operating Temperature: 85°C; Mfr. Part No.: TPS63001DRCR; Min Operating Temperature: -40°C; Min Output Voltage: 3.3V; Nominal Output Voltage: 3.3V; Number of Pins: 10; Operating Supply Voltage: 5.5V; Output Current: 1.2A; Output Voltage: 3.3V; Package Quantity: 1; Packaging: Tape and Reel; Radiation Hardening: No; REACH SVHC: No SVHC; Topology: Buck-Boost; Weight: 0.000921oz; Library Name: Budapest University of Technology and Economics
